# Altium schematic sheet I2S_Shifters.SchDoc
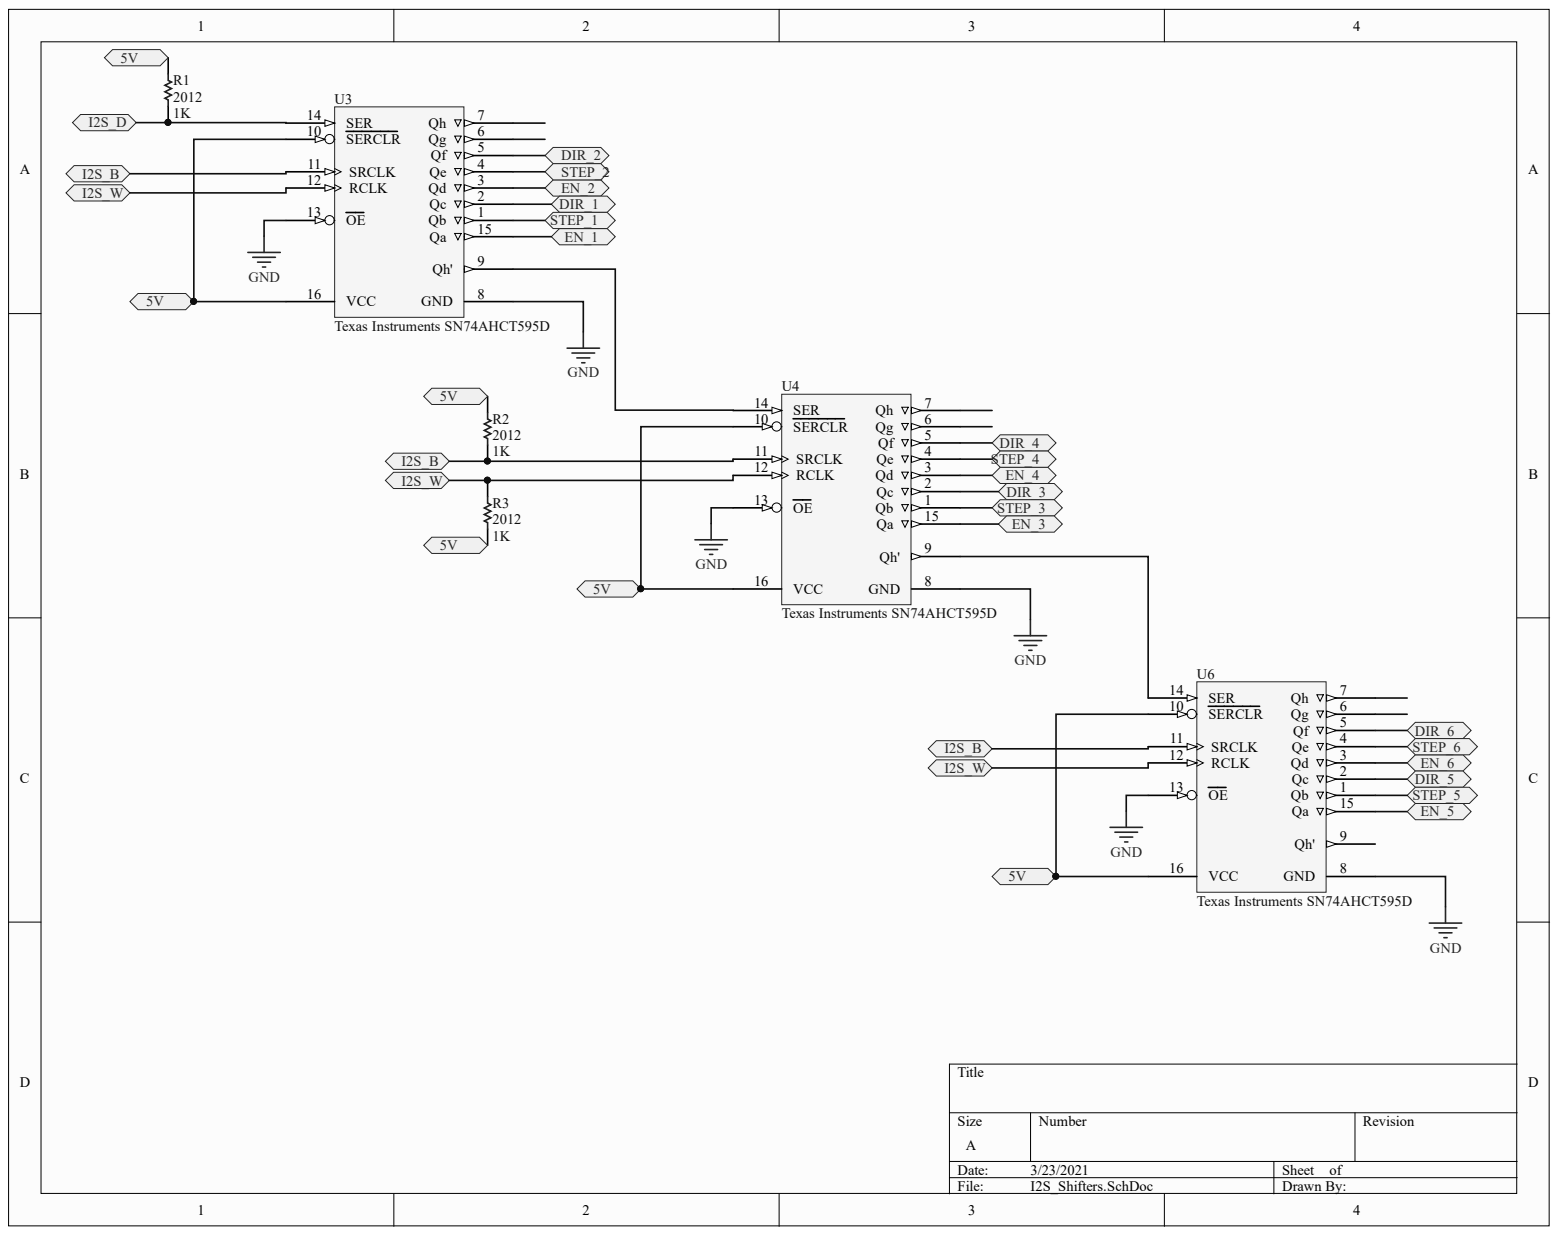
[diagram p0: I2S_Shifters.SchDoc]

## Parts
R1  Case/Package (SI): 2012  Resistance: 1K  [RESC2013X70X40ML20T20]  (hidden comment: Panasonic ERJ-6GEYJ822V)
R2  Case/Package (SI): 2012  Resistance: 1K  [RESC2013X70X40ML20T20]  (hidden comment: Panasonic ERJ-6GEYJ822V)
R3  Case/Package (SI): 2012  Resistance: 1K  [RESC2013X70X40ML20T20]  (hidden comment: Panasonic ERJ-6GEYJ822V)
U3  Texas Instruments SN74AHCT595D  Manufacturer Part Number: SN74AHCT595D  [PCB-u51jluiokpefud6e4fih-1]
U4  Texas Instruments SN74AHCT595D  Manufacturer Part Number: SN74AHCT595D  [PCB-u51jluiokpefud6e4fih-1]
U6  Texas Instruments SN74AHCT595D  Manufacturer Part Number: SN74AHCT595D  [PCB-u51jluiokpefud6e4fih-1]

## Ports
5V, DIR_1, DIR_2, DIR_3, DIR_4, DIR_5, DIR_6, EN_1, EN_2, EN_3, EN_4, EN_5, EN_6, I2S_B, I2S_D, I2S_W, STEP_1, STEP_2, STEP_3, STEP_4, STEP_5, STEP_6

## Nets
GND: U3.8(GND) U3.13(OE) U4.8(GND) U4.13(OE) U6.8(GND) U6.13(OE)
5V: R1.1 R2.1 R3.2 U3.10(SERCLR) U3.16(VCC) U4.10(SERCLR) U4.16(VCC) U6.10(SERCLR) U6.16(VCC) ; port 5V
DIR_1: U3.2(Qc) ; port DIR_1
DIR_2: U3.5(Qf) ; port DIR_2
DIR_3: U4.2(Qc) ; port DIR_3
DIR_4: U4.5(Qf) ; port DIR_4
DIR_5: U6.2(Qc) ; port DIR_5
DIR_6: U6.5(Qf) ; port DIR_6
EN_1: U3.15(Qa) ; port EN_1
EN_2: U3.3(Qd) ; port EN_2
EN_3: U4.15(Qa) ; port EN_3
EN_4: U4.3(Qd) ; port EN_4
EN_5: U6.15(Qa) ; port EN_5
EN_6: U6.3(Qd) ; port EN_6
I2S_B: R2.2 U3.11(SRCLK) U4.11(SRCLK) U6.11(SRCLK) ; port I2S_B
I2S_D: R1.2 U3.14(SER) ; port I2S_D
I2S_W: R3.1 U3.12(RCLK) U4.12(RCLK) U6.12(RCLK) ; port I2S_W
STEP_1: U3.1(Qb) ; port STEP_1
STEP_2: U3.4(Qe) ; port STEP_2
STEP_3: U4.1(Qb) ; port STEP_3
STEP_4: U4.4(Qe) ; port STEP_4
STEP_5: U6.1(Qb) ; port STEP_5
STEP_6: U6.4(Qe) ; port STEP_6
NetU3_9: U3.9(Qh') U4.14(SER)
NetU4_9: U4.9(Qh') U6.14(SER)

Not connected: U3 6(Qg) 7(Qh); U4 6(Qg) 7(Qh); U6 6(Qg) 7(Qh) 9(Qh')

## Library data of the parts
R1-R3 = CMP-3687578-2: Thick Film Resistors - SMD 0805 8.2Kohms 5% Tol; Lead-Free Status: Lead Free; Power Rating: 0.125 W; Voltage Rating (DC): 150.0 V; Case/Package: 0805; Component Kind: Standard; Library Name: CommunityVault; Lifecycle Status: Not Listed by Manufacturer; Manufacturer Part Number: ERJ-6GEYJ822V; Mounting Style: Surface Mount; OctopartID: 0556cf11906ada91; Octopart Url: https://octopart.com/erj-6geyj822v-panasonic-55423066; Packaging: Cut Tape (CT); Resistance Tolerance: ±5%; RoHS: Compliant; Size-Height: 0.0006 m; Size-Length: 0.002 m; Size-Width: 0.00125 m
U3 U4 U6 = CMP-13610480-8: Shift Register Single 8-Bit Serial to Serial/Parallel 16-Pin SOIC Tube; Frequency: 170 MHz; Lead-Free Status: Lead Free; Number of Bits: 8; Supply Voltage (DC): 5.00 V, 5.50 V (max); Voltage Nodes: 5.00 V; Case/Package: SOIC; Component Kind: Standard; Library Name: CommunityVault; Lifecycle Status: Active; Mounting Style: Surface Mount; Number of Pins: 16; OctopartID: 6ed9a7d4e831b11e; Octopart URL: http://octopart.com/sn74ahct595d-texas+instruments-500622; Packaging: Tube; REACH SVHC Compliance: No SVHC; RoHS: Compliant
